# Altium schematic sheet sdd_power_supply.SchDoc(sdd_power_supply)
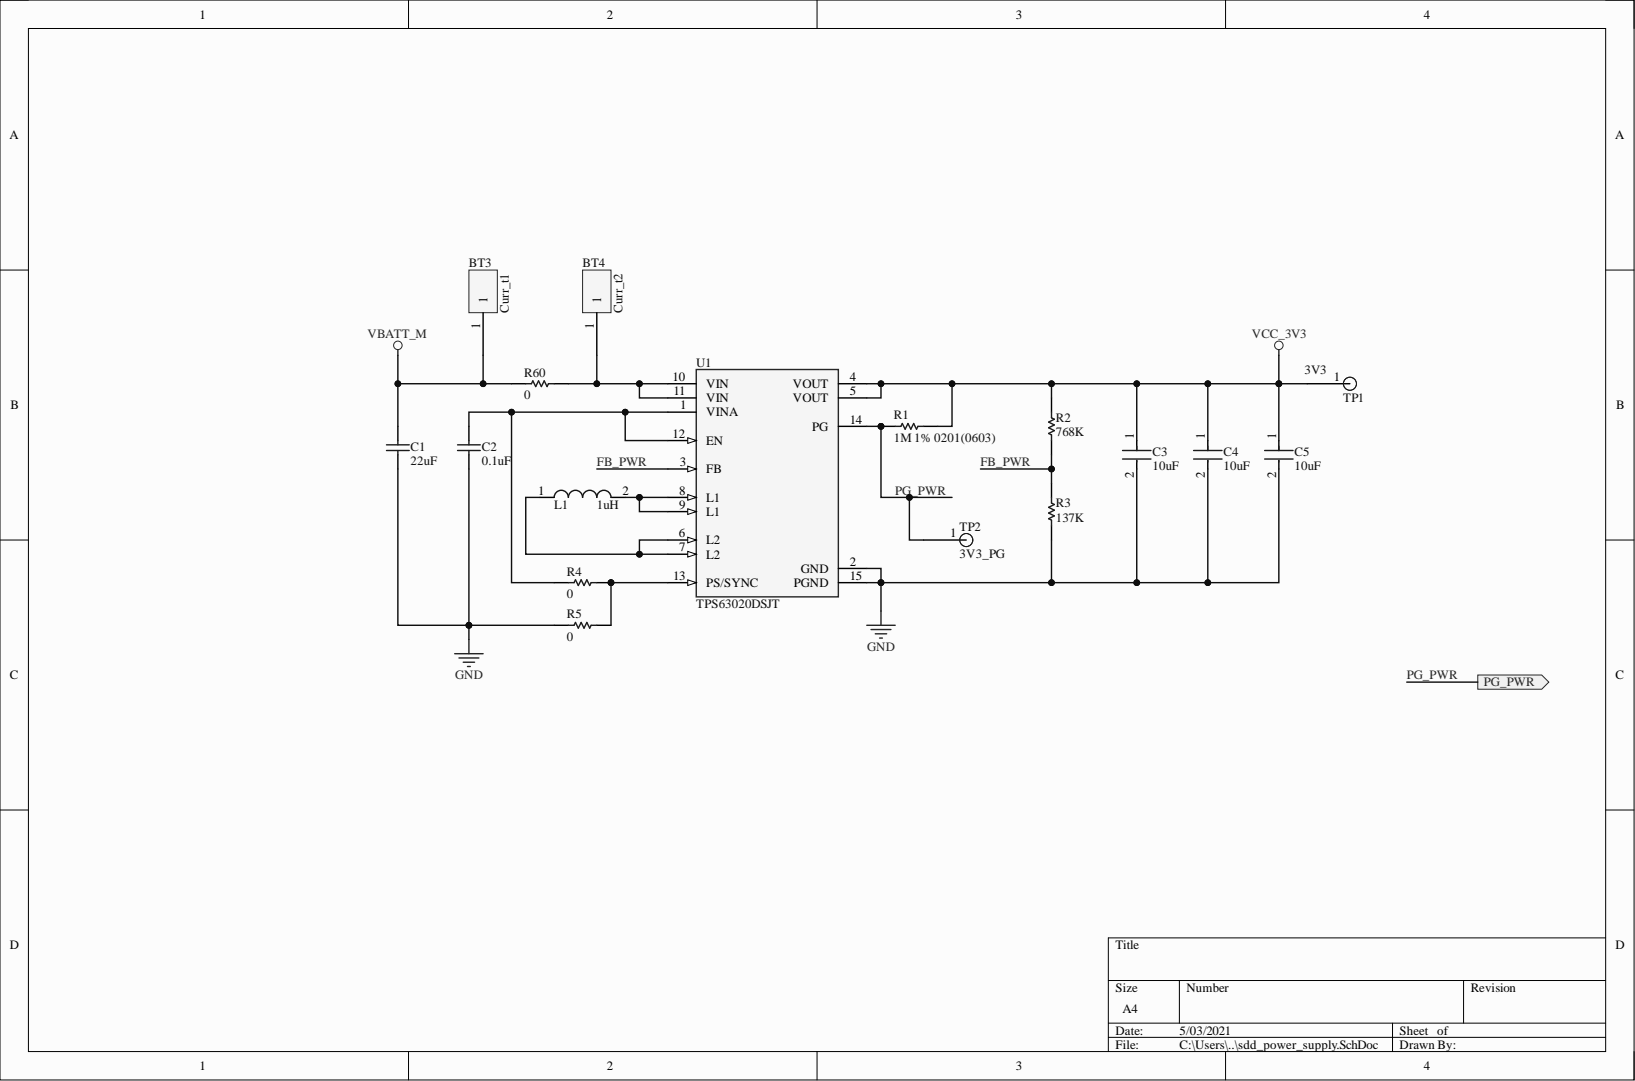
[diagram p0: sdd_power_supply.SchDoc]

## Parts
BT3  Curr_t1  [BattConn1]
BT4  Curr_t2  [BattConn1]
C1  22uF  [CAPC1608X100X20ML10]
C2  0.1uF  [CAPC1608X90X35ML15T15]
C3  10uF  [FP-GRJ155-0_2-MFG]
C4  10uF  [FP-GRJ155-0_2-MFG]
C5  10uF  [FP-GRJ155-0_2-MFG]
L1  1uH  [SMD 3012]
R1  1M 1% 0201(0603)  [RESC0201(0603)_L]
R2  768K  [RESC1005X40X25LL05T05]
R3  137K  [RESC1005X40X25NL05T05]
R4  0  [RESC1005X40X25NL05T10]
R5  0  [RESC1005X40X25NL05T10]
R60  0  [RESC1005X40X25NL05T10]
TP1  3V3  [Testpoint1]  (no BOM)
TP2  3V3_PG  [Testpoint2]  (no BOM)
U1  TPS63020DSJT  [FP-DSJ0014A-MFG]

## Ports
PG_PWR

## Nets
GND: C1.1 C2.1 C3.2 C4.2 C5.2 R3.1 R5.1 U1.2(GND) U1.15(PGND)
VBATT_M: BT3.1 C1.2 R60.1
VCC_3V3: C3.1 C4.1 C5.1 R1.1 R2.2 TP1.1 U1.4(VOUT) U1.5(VOUT)
FB_PWR: R2.1 R3.2 U1.3(FB)
PG_PWR: R1.2 TP2.1 U1.14(PG) ; port PG_PWR
NetBT4_1: BT4.1 R60.2 U1.10(VIN) U1.11(VIN)
NetC2_2: C2.2 R4.1 U1.1(VINA) U1.12(EN)
NetL1_1: L1.1 U1.6(L2) U1.7(L2)
NetL1_2: L1.2 U1.8(L1) U1.9(L1)
NetR4_2: R4.2 R5.2 U1.13(PS/SYNC)

## Library data of the parts
BT3 BT4 = BattConn1: Component Kind: Standard; Library Name: Batt_Conn.IntLib
C1 = CMP-2000-05441-1: Chip Capacitor, 22 uF, +/- 20%, 10 V, -55 to 85 degC, 0603 (1608 Metric), RoHS, Tape and Reel; Manufacturer: TDK; PCN Part Number: MLCCPartNumberChange30/Nov/2012; Capacitance: 22µF; Design Resources: 20WNon-IsolatedBuckLEDDriverUsingPLLNK460KG; Kits: 445-9014-KIT-ND-KITMLCC100PF-22UF060310-50V; Online Catalog: CSeries,GeneralPurpose; Other Names: 445-9077-1; Product Training Modules: CSeriesGeneralApplicationsSEAT,CCV,andTVCLDesignToolsGuidetoReplacinganElectrolyticCapacitorwithanMLCC; Temperature Coefficient: X5R; Voltage - Rated: 10V; Applications: GeneralPurpose; Category: Capacitors; Component Kind: Standard; Datasheet URL: http://download.siliconexpert.com/pdfs/2015/4/21/15/54/45/779/tdk_/manual/37024850441143360mlcc_commercial_general_en.pdf; Datasheet Version: Mar-15; Family: CeramicCapacitors; Features: -; Height - Seated (Max): -; Lead Spacing: -; Lead Style: -; Library Name: Altium Content Vault; Manufacturer URL: http://www.tdk.com/; Mounting Type: SurfaceMount,MLCC; Operating Temperature: -55degC~85degC; Package / Case: 0603(1608Metric); Package Description: 2-Pin Surface Mount Device, Body 1.6 x 0.8 mm; Package Reference: 603; Packaging: CutTape(CT); Ratings: -; RoHS Information: RoHSCertificate-MLCC; Series: C; Size / Dimension: 0.063"Lx0.031"W(1.60mmx0.80mm); Standard Package: 1; Thickness (Max): 0.035"(0.90mm); Tolerance: ±20%
C2 = CMP-2006-04492-1: Manufacturer: AVX Interconnect / Elco; Part Number: 0603YC104K4T4A; Component Kind: Standard; Library Name: Altium Content Vault
C3-C5 = CMP-06035-062410-1: CAP CER 10UF 6.3V X5R 0402; Tolerance: 20%; Capacitance: 10uF; Case Code (Imperial): 0402; Case Code (Metric): 1005; Case/Package: 0402; Component Kind: Standard; Features: Soft Termination; Length: 0.039inch; Library Name: Altium Content Vault; Max Operating Temperature: 85°C; Min Operating Temperature: -55°C; Mount: Surface Mount; Packaging: Cut Tape; RoHS Compliant: Yes; Thickness: 0.028inch; Voltage Rating: 6.3V; Width: 0.02inch
L1 = CMP-1393-00006-1: Power Multilayer Inductor WE-MAPI, L=1.0µH; Manufacturer: Wuerth Elektronik; PartNumber: 74438334010; Inductance: 1.0ÂµH; Component Kind: Standard; ComponentLink1Description: Manufacturer URL; ComponentLink1URL: http://www.we-online.com; ComponentLink2Description: Datasheet; ComponentLink2URL: http://katalog.we-online.de/kataloge/eisos/media/pdf/74438334010.pdf; Library Name: Altium Content Vault; PackageReference: SMD 3012
R1 = CMP-1010-00755-1: 1M 0.05W 1% 0201 (0603 Metric)  SMD; Case-EIA: 0201; Case-Metric: 0603; Value: 1000000.00 Ohm; Component Kind: Standard; Library Name: Altium Content Vault; Power: 0.05 W; Technology: SMT; Tolerance: 1 %
R2 = CMP-1011-00836-2: Manufacturer: Vishay Dale; Part Number: CRCW0402768KFKED; Component Kind: Standard; Library Name: Altium Content Vault
R3 = CMP-1011-00750-4: Chip Resistor, 137 KOhm, +/- 1%, 63 mW, -55 to 155 degC, 0402 (1005 Metric), RoHS, Tape and Reel; Manufacturer: Basic Passives; PartNumber: CRCW0402137KFKED; Case\/Package: 0402; Power Rating: 63 mW; Voltage Rating: 50 V; Case Code (Imperial): 0402; Case Code (Metric): 1005; Component Kind: Standard; ComponentLink1Description: Manufacturer URL; ComponentLink1URL: http://www.vishay.com/; ComponentLink2Description: Datasheet; ComponentLink2URL: http://www.vishay.com/docs/20035/dcrcwe3.pdf; DatasheetVersion: 06/2012; Height: 0.35 mm; Length: 1 mm; Library Name: Altium Content Vault; Max Operating Temperature: 155 degC; Min Operating Temperature: -55 degC; Mount: Surface Mount; Mounting Technology: Surface Mount; Package Quantity: 10000; PackageDescription: 2-Pin Surface Mount Device, Body 1 x 0.5 mm; PackageReference: 0402; Packaging: Tape and Reel; Pins: 2; Radiation Hardening: No; REACH SVHC: Unknown; Resistance: 137 KOhm; RoHSCompliant: true; Tolerance: 1%; Weight: 2.3E-05oz; Width: 0.5 mm
R4 R5 R60 = CMP-1011-00001-2: Manufacturer: Yageo / Phycomp; Part Number: RC0402JR-070RL; Component Kind: Standard; Library Name: Altium Content Vault
TP1 = Testpoint1: Library Name: TestPoint.IntLib
TP2 = Testpoint2: Library Name: TestPoint_Round.IntLib
U1 = CMP-2000-07282-2: IC REG BCK BST ADJ 3.5A 14VSON; Manufacturer: Texas Instruments; Max Junction Temp: +125°C; Max Supply Voltage: 5.5V; Min Supply Voltage: 1.8V; Nominal Supply Current: 25uA; Number Of Outputs: 1; Output Current: 3A; Output Type: AdjustableProgrammable; Output Voltage: 1.2-5.5V; Standoff Height: 0mm; Switching Frequency: 2400kHz; Switching Topology: Buck-Boost; Ambient Temperature Range High: +85°C; Ambient Temperature Range Low: -40°C; Automotive: No; Category: IC; Component Kind: Standard; ComponentLink2Description: Datasheet; ComponentLink2URL: https://www.ti.com/lit/ds/symlink/tps63020.pdf; Device Class L1: Integrated Circuits (ICs); Device Class L2: Power Management ICs; Device Class L3: Voltage Regulators - Switching; Digikey Description: IC REG BCK BST ADJ 3.5A 14VSON; Height: 1mm; Lead Free: Yes; Library Name: Altium Content Vault; Manufacturer Part Number: TPS63020DSJT; Mouser Description: Voltage Regulators - Switching Regulators 93% Eff Buck-Boost Converter; Octopart: https://octopart.com/tps63020dsjt-texas+instruments-12976119; Package: DSJ0014A; RoHS: TRUE; Temperature: -40°C to +85°C; Temperature Range High: +85°C; Temperature Range Low: -40°C
